# Altium schematic sheet NIM_to_LVCMOS.SchDoc(NIM_to_LVCMOS)
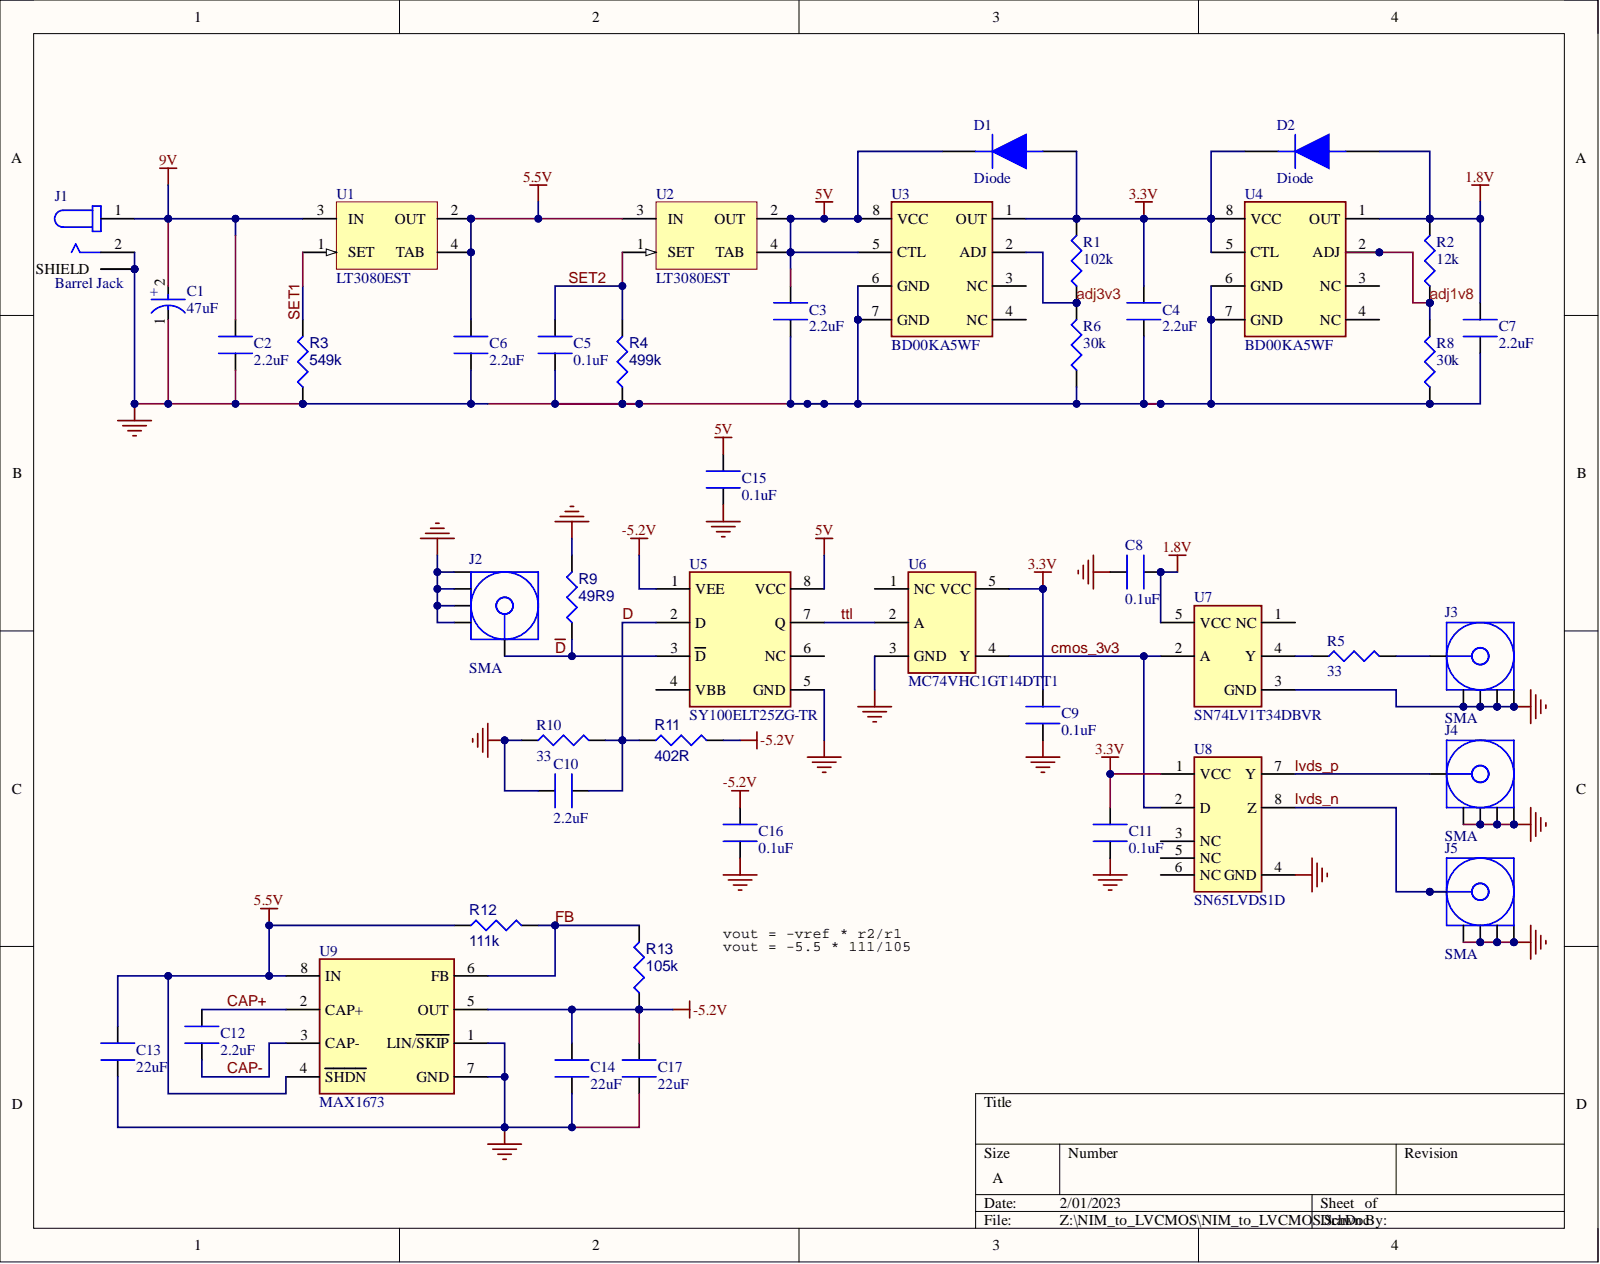
[diagram p0: NIM_to_LVCMOS.SchDoc]

## Parts
C1  47uF  [FP-T495X476K035ATE300-MFG]
C2  2.2uF  [CL21B225KAFNFNE]
C3  2.2uF  [CL21B225KAFNFNE]
C4  2.2uF  [CL21B225KAFNFNE]
C5  0.1uF  [CL21B104KACNNNC]
C6  2.2uF  [CL21B225KAFNFNE]
C7  2.2uF  [CL21B225KAFNFNE]
C8  0.1uF  [CL21B104KACNNNC]
C9  0.1uF  [CL21B104KACNNNC]
C10  2.2uF  [CL21B225KAFNFNE]
C11  0.1uF  [CL21B104KACNNNC]
C12  2.2uF  [CL21B225KAFNFNE]
C13  22uF  [GRM21BR60J226ME39L]
C14  22uF  [GRM21BR60J226ME39L]
C15  0.1uF  [CL21B104KACNNNC]
C16  0.1uF  [CL21B104KACNNNC]
C17  22uF  [GRM21BR60J226ME39L]
D1  Diode  [BA679S-GS08]
D2  Diode  [BA679S-GS08]
J1  Barrel Jack  Connector Type: Jack  [PJ-063AH]
J2  SMA  [RF249BT0050GHDW]
J3  SMA  [RF249BT0050GHDW]
J4  SMA  [RF249BT0050GHDW]
J5  SMA  [RF249BT0050GHDW]
R1  102k  [R0805]
R2  12k  [R0805]
R3  549k  [R0805]
R4  499k  [R0805]
R5  33  [R0805]
R6  30k  [R0805]
R8  30k  [R0805]
R9  49R9  [R0805]
R10  33  [R0805]
R11  402R  [R0805]
R12  111k  [R0805]
R13  105k  [R0805]
U1  LT3080EST  [LT-ST-3-SOT-223_N]
U2  LT3080EST  [LT-ST-3-SOT-223_N]
U3  BD00KA5WF  [BD00KA5WF-E2]
U4  BD00KA5WF  [BD00KA5WF-E2]
U5  SY100ELT25ZG-TR  [SY100ELT25ZG-TR]
U6  MC74VHC1GT14DTT1  [MC74VHC1GT14DTT1]
U7  SN74LV1T34DBVR  [SN74LV1T34-DBV]
U8  SN65LVDS1D  [SN65LVDS1D]
U9  MAX1673  [MAX1673ESA+T]

## Nets
1.8V: C7.2 C8.2 D2.2 R2.2 U4.1(OUT) U7.5(VCC)
3.3V: C4.1 C9.1 C11.1 D1.2 D2.1 R1.2 U3.1(OUT) U4.5(CTL) U4.8(VCC) U6.5(VCC) U8.1(VCC)
5.5V: C6.1 C13.1 R12.1 U1.2(OUT) U1.4(TAB) U2.3(IN) U9.4(SHDN) U9.8(IN)
5V: C3.1 C15.2 D1.1 U2.2(OUT) U2.4(TAB) U3.5(CTL) U3.8(VCC) U5.8(VCC)
9V: C1.2 C2.1 J1.1 U1.3(IN)
-5.2V: C14.1 C16.2 C17.1 R11.2 R13.1 U5.1(VEE) U9.5(OUT)
GND: C1.1 C2.2 C3.2 C4.2 C5.2 C6.2 C7.1 C8.1 C9.2 C10.2 C11.2 C13.2 C14.2 C15.1 C16.1 C17.2 J1.2 J1.S1 J1.S2 J2.2 J2.3 J2.4 J2.5 J3.2 J3.3 J3.4 J3.5 J4.2 J4.3 J4.4 J4.5 J5.2 J5.3 J5.4 J5.5 R3.1 R4.1 R6.1 R8.1 R9.2 R10.1 U3.6(GND) U3.7(GND) U4.6(GND) U4.7(GND) U5.5(GND) U6.3(GND) U7.3(GND) U8.4(GND) U9.1(LIN/SKIP) U9.7(GND)
adj1v8: R2.1 R8.2 U4.2(ADJ)
adj3v3: R1.1 R6.2 U3.2(ADJ)
CAP+: C12.1 U9.2(CAP+)
CAP-: C12.2 U9.3(CAP-)
cmos_3v3: U6.4(Y) U7.2(A) U8.2(D)
D: C10.1 R10.2 R11.1 U5.2(D)
~{D}: J2.1 R9.1 U5.3(D)
FB: R12.2 R13.2 U9.6(FB)
lvds_n: J5.1 U8.8(Z)
lvds_p: J4.1 U8.7(Y)
SET1: R3.2 U1.1(SET)
SET2: C5.1 R4.2 U2.1(SET)
ttl: U5.7(Q) U6.2(A)
NetJ3_1: J3.1 R5.2
NetR5_1: R5.1 U7.4(Y)

Not connected: U3 3(NC) 4(NC); U4 3(NC) 4(NC); U5 4(VBB) 6(NC); U6 1(NC); U7 1(NC); U8 3(NC) 5(NC) 6(NC)

## Library data of the parts
C1 = CMP-2000-05171-2: CAP TANT 47UF 10% 35V 2917; Dielectric Material: Tantalum; Dissipation Factor: 8%; ESR (Equivalent Series Resistance): 300mR; Leakage Current: 16.5uA; Life (Hours): 2000Hour; Polarity: Polar; Ripple Current: 297mA; Capacitance: 47uF; Case Code (Imperial): 2917; Case Code (Metric): 7343; Case/Package: 7343-43; Component Kind: Standard; Construction: Flat; Depth: 4.3mm; Features: General Purpose; Height: 4mm; Height - Seated (Max): 0.169inch; Lead Free: Lead Free; Lead Pitch: 3.8mm; Length: 7.3mm; Library Name: Altium Content Vault; Max Operating Temperature: 125°C; Min Operating Temperature: -55°C; Mount: Surface Mount; Number of Pins: 2; Package Quantity: 1; Packaging: Tape and Reel; Radiation Hardening: No; REACH SVHC: No SVHC; RoHS Compliant: Yes; Series: T495; Termination: SMD/SMT; Tolerance: 10%; Voltage: 35V; Voltage Rating: 35V; Voltage Rating (DC): 35V; Width: 0.169inch
C2-C4 C6 C7 C10 C12 = CL21B225KAFNFNE: CAP CER 2.2UF 25V X7R 0805; Manufacturer: Samsung; Tolerance: 10%; Capacitance: 2.2uF; Case Code (Imperial): 0805; Case Code (Metric): 2012; Case/Package: 0805; Category: Ceramic Capacitors; Component Kind: Standard; ComponentLink1Description: https://datasheet.ciiva.com/25798/cl21b225kafnfne-25798721.pdf?src-supplier=Digi-Key; ComponentLink1URL: https://datasheet.ciiva.com/25798/cl21b225kafnfne-25798721.pdf?src-supplier=Digi-Key; Construction: Flat; Depth: 1.25mm; Dielectric: X7R; Height: 1.25mm; Lead Free: Lead Free; Length: 0.079inch; Manufacturer Part Number: CL21B225KAFNFNE; Max Operating Temperature: 125°C; Min Operating Temperature: -55°C; Mount: Surface Mount; Package Quantity: 1; Packaging: Tape and Reel; Pricing 1: 2000=0.02328, 4000=0.02275, 8000=0.02221, 12000=0.02168, 16000=0.02114 (USD); Radiation Hardening: No; RoHS: Yes; RoHS Compliant: Yes; Series: CL; Stock 1: 46000; Supplier 1: Avnet; Supplier Part Number 1: CL21B225KAFNFNE; Thickness: 0.053inch; Voltage Rating: 25V; Width: 0.049inch; Library Name: NIM_to_LVCMOS_Schlib.SchLib
C5 C8 C9 C11 C15 C16 = CL21B104KACNNNC: CAP CER 0.1UF 25V X7R 0805; Manufacturer: Samsung; Capacitance: 100nF; Tolerance: 10%; Case Code (Imperial): 0805; Case Code (Metric): 2012; Case/Package: 0805; Category: Ceramic Capacitors; Component Kind: Standard; ComponentLink1Description: https://datasheet.ciiva.com/25801/cl21b104kacnnnc-25801824.pdf?src-supplier=Digi-Key; ComponentLink1URL: https://datasheet.ciiva.com/25801/cl21b104kacnnnc-25801824.pdf?src-supplier=Digi-Key; ComponentLink2Description: https://datasheet.ciiva.com/15216/getdatasheetpartid-355204-15216236.pdf?src-supplier=Verical; ComponentLink2URL: https://datasheet.ciiva.com/15216/getdatasheetpartid-355204-15216236.pdf?src-supplier=Verical; Construction: Flat; Contact Plating: Tin; Depth: 1.25mm; Dielectric: X7R; Height: 0.85mm; Length: 2mm; Manufacturer Part Number: CL21B104KACNNNC; Max Operating Temperature: 125°C; Min Operating Temperature: -55°C; Mount: Surface Mount; Package Quantity: 1; Packaging: Tape and Reel; Pricing 1: 1=0.00700, 4000=0.00700 (USD); Radiation Hardening: No; RoHS: Yes; RoHS Compliant: Yes; Series: CL; Stock 1: 16000; Supplier 1: Newark; Supplier Part Number 1: 82AC9426; Thickness: 0.037inch; Voltage: 25V; Voltage Rating: 25V; Width: 0.049inch; Library Name: NIM_to_LVCMOS_Schlib.SchLib
C13 C14 C17 = GRM21BR60J226ME39L: Multilayer Ceramic Capacitors MLCC Surface Mount 0805 22uF 6.3 Volts X5R 20%; Manufacturer: Murata; Voltage: 6.3V; Capacitance: 22uF; Case Code (Imperial): 0805; Case Code (Metric): 2012; Case/Package: 0805; Category: Ceramic Capacitors; Component Kind: Standard; Construction: Flat; Depth: 1.25mm; Dielectric: X5R; Height: 1.25mm; Lead Free: Lead Free; Length: 2mm; Manufacturer Part Number: GRM21BR60J226ME39L; Material: Ceramic; Max Operating Temperature: 85°C; Min Operating Temperature: -55°C; Mount: Surface Mount; Package Quantity: 1; Packaging: Tape and Reel; Pricing 1: 1=0.30000, 25=0.16900, 50=0.14500, 100=0.12100, 250=0.10500, 500=0.08800 (USD); Radiation Hardening: No; Reach SVHC Compliant: Yes; RoHS: Yes; RoHS Compliant: Yes; Series: GRM; Stock 1: 5011; Supplier 1: Newark; Supplier Part Number 1: 24R6338; Termination: SMD/SMT; Thickness: 0.053inch; Tolerance: 20%; Voltage Rating: 6.3V; Voltage Rating (DC): 6.3V; Width: 0.049inch; Library Name: NIM_to_LVCMOS_Schlib.SchLib
D1 D2 = BA679S-GS08: Diode PIN Attenuator 30V 2-Pin Mini-MELF SOD-80 T/R; Manufacturer: Vishay Semiconductors; Current Rating: 50A; Diode Type: PIN - Single; Element Configuration: Single; Forward Current: 50mA; Forward Voltage: 1V; Category: Diodes; Component Kind: Standard; ComponentLink1Description: https://datasheet.ciiva.com/1240/1749354-1240855.pdf?src-supplier=Newark; ComponentLink1URL: https://datasheet.ciiva.com/1240/1749354-1240855.pdf?src-supplier=Newark; ComponentLink2Description: https://datasheet.ciiva.com/9424/ba679-9424319.pdf?src-supplier=Avnet; ComponentLink2URL: https://datasheet.ciiva.com/9424/ba679-9424319.pdf?src-supplier=Avnet; ComponentLink3Description: https://datasheet.ciiva.com/1179/ba679-1179241.pdf?src-supplier=Element14; ComponentLink3URL: https://datasheet.ciiva.com/1179/ba679-1179241.pdf?src-supplier=Element14; Contact Plating: Tin,Silver; Manufacturer Part Number: BA679S-GS08; Max Current Rating: 50mA; Max Operating Temperature: 125°C; Max Repetitive Reverse Voltage (Vrrm): 30V; Min Operating Temperature: -55°C; Mount: Surface Mount; Number of Pins: 2; Package Quantity: 2500; Packaging: Tape and Reel; Pricing 1: 1=0.53000, 10=0.43000, 100=0.29270, 500=0.21954, 1000=0.16466 (USD); Reverse Voltage: 30V; Reverse Voltage (DC): 30V; RoHS: Yes; RoHS Compliant: Yes; Series: -; Stock 1: 7528; Supplier 1: Digi-Key; Supplier Part Number 1: 112-BA679S-GS08CT-ND; Library Name: NIM_to_LVCMOS_Schlib.SchLib
J1 = PJ-063AH: 2.0 x 6.5 mm, 8.0 A, Horizontal, Through Hole, Shielded, Kinked Pins, Dc Power Jack Connector; Manufacturer: CUI; Current Rating: 8A; Gender: Male; Insulation Resistance: 100MR; Number of Conductors: 2; Voltage Rating: 24V; Category: Connectors; Component Kind: Standard; ComponentLink1Description: https://www.cui.com/product/resource/digikeypdf/pj-063ah.pdf?src-supplier=Digi-Key; ComponentLink1URL: https://www.cui.com/product/resource/digikeypdf/pj-063ah.pdf?src-supplier=Digi-Key; ComponentLink2Description: https://www.cui.com/product/resource/digikeypdf/pj-063ah.pdf?src-supplier=Arrow+Electronics; ComponentLink2URL: https://www.cui.com/product/resource/digikeypdf/pj-063ah.pdf?src-supplier=Arrow+Electronics; ComponentLink3Description: https://www.cui.com/product/resource/digikeypdf/pj-063ah.pdf?src-supplier=Mouser; ComponentLink3URL: https://www.cui.com/product/resource/digikeypdf/pj-063ah.pdf?src-supplier=Mouser; ComponentLink4Description: https://www.cui.com/product/resource/digikeypdf/pj-063ah.pdf?src-supplier=Onlinecomponents.com; ComponentLink4URL: https://www.cui.com/product/resource/digikeypdf/pj-063ah.pdf?src-supplier=Onlinecomponents.com; ComponentLink5Description: http://www.cui.com/Product/Resource/FuturePDF/PJ-063AH.pdf?src-supplier=Future+Electronics; ComponentLink5URL: http://www.cui.com/Product/Resource/FuturePDF/PJ-063AH.pdf?src-supplier=Future+Electronics; ComponentLink6Description: https://www.cui.com/product/resource/digikeypdf/pj-063ah.pdf?src-supplier=Verical; ComponentLink6URL: https://www.cui.com/product/resource/digikeypdf/pj-063ah.pdf?src-supplier=Verical; ComponentLink7Description: https://www.cui.com/product/resource/digikeypdf/pj-063ah.pdf?src-supplier=Quest; ComponentLink7URL: https://www.cui.com/product/resource/digikeypdf/pj-063ah.pdf?src-supplier=Quest; ComponentLink8Description: https://www.cui.com/product/resource/digikeypdf/pj-063ah.pdf?src-supplier=NAC+Semi; ComponentLink8URL: https://www.cui.com/product/resource/digikeypdf/pj-063ah.pdf?src-supplier=NAC+Semi; Contact Gender: Male; Contact Material: Copper; Contact Plating: Nickel; Flammability Rating: UL94 V-0; Housing Color: Black; Housing Material: Thermoplastic; Lead Free: Lead Free; Manufacturer Part Number: PJ-063AH; Max Operating Temperature: 85°C; Min Operating Temperature: -25°C; Mount: Through Hole; Orientation: Horizontal; Package Quantity: 500; RoHS: Yes; RoHS Compliant: Yes; Series: -; Shielding: Shielded; Supplier 1: Mouser; Supplier Part Number 1: 490-PJ-063AH; Termination: Through Hole; Library Name: NIM_to_LVCMOS_Schlib.SchLib
J2-J5 = RF2-49B-T-00-50-G-HDW: Conn SMA 0Hz to 6GHz 50Ohm Solder RA Thru-Hole RCP Gold; Manufacturer: Adam Equipment; Category: RF / Coaxial Connectors; Component Kind: Standard; Manufacturer Part Number: RF2-49B-T-00-50-G-HDW; Pricing 1: 1=3.05500, 10=2.75100, 25=2.58300, 50=2.49800, 100=2.35600, 300=2.04400, 500=1.97200 (USD); RoHS: No; Stock 1: 26; Supplier 1: Arrow Electronics; Supplier Part Number 1: RF2-49B-T-00-50-G-HDW; Library Name: NIM_to_LVCMOS_Schlib.SchLib
R1 = RC0805FR-07102KL: Res Thick Film 0805 102K Ohm 1% 1/8W ±100ppm/°C SMD Paper T/R; Manufacturer: Yageo; Max Power Dissipation: 125mW; Resistance: 102kR; Voltage Rating: 150V; Case Code (Imperial): 0805; Case Code (Metric): 2012; Case/Package: 0805; Category: Chip SMD Resistors; Component Kind: Standard; ComponentLink1Description: https://datasheet.ciiva.com/2457/pyu-r-int-thick-7-2457663.pdf?src-supplier=Digi-Key; ComponentLink1URL: https://datasheet.ciiva.com/2457/pyu-r-int-thick-7-2457663.pdf?src-supplier=Digi-Key; ComponentLink2Description: https://datasheet.ciiva.com/2457/pyu-r-int-thick-7-2457664.pdf?src-supplier=Digi-Key; ComponentLink2URL: https://datasheet.ciiva.com/2457/pyu-r-int-thick-7-2457664.pdf?src-supplier=Digi-Key; ComponentLink3Description: https://datasheet.ciiva.com/2457/pyu-r-int-thick-7-2457662.pdf?src-supplier=Digi-Key; ComponentLink3URL: https://datasheet.ciiva.com/2457/pyu-r-int-thick-7-2457662.pdf?src-supplier=Digi-Key; Construction: Rectangular; Contact Plating: Tin,Matte,Lead; Depth: 1.25mm; Features: Moisture Resistant; Height: 0.024inch; Lead Free: Lead Free; Length: 2mm; Manufacturer Part Number: RC0805FR-07102KL; Max Operating Temperature: 155°C; Military Standard: Not; Min Operating Temperature: -55°C; Number of Terminations: 2; Package Quantity: 1; Packaging: Tape and Reel; Power Rating: 125mW; Pricing 1: 10=0.10000, 25=0.02900, 50=0.02100, 100=0.01200 (USD); Radiation Hardening: No; Resistor Type: Thick Film; RoHS: Yes; RoHS Compliant: Yes; Series: RC; Stock 1: 24480; Supplier 1: Newark; Supplier Part Number 1: 32AK1123; Temperature Coefficient: 100ppm/°C; Termination: SMD/SMT; Tolerance: 1%; Voltage Rating (DC): 150V; Width: 0.049inch; Library Name: NIM_to_LVCMOS_Schlib.SchLib
R2 = RC0805JR-0712KL: Res Thick Film 0805 12K Ohm 5% 0.125W(1/8W) ±100ppm/C Pad SMD T/R; Manufacturer: Yageo; Max Power Dissipation: 125mW; Resistance: 12kR; Temperature Coefficient: 100ppm/°C; Voltage Rating: 150V; Case Code (Imperial): 0805; Case Code (Metric): 2012; Case/Package: 0805; Category: Chip SMD Resistors; Component Kind: Standard; ComponentLink1Description: https://datasheet.ciiva.com/7941/442941-7941880.pdf?src-supplier=Element14; ComponentLink1URL: https://datasheet.ciiva.com/7941/442941-7941880.pdf?src-supplier=Element14; ComponentLink2Description: https://datasheet.ciiva.com/7941/442941-7941881.pdf?src-supplier=Element14; ComponentLink2URL: https://datasheet.ciiva.com/7941/442941-7941881.pdf?src-supplier=Element14; ComponentLink3Description: https://datasheet.ciiva.com/17082/rc0805-17082649.pdf?src-supplier=Future+Electronics; ComponentLink3URL: https://datasheet.ciiva.com/17082/rc0805-17082649.pdf?src-supplier=Future+Electronics; Construction: Rectangular; Contact Plating: Tin,Lead; Depth: 1.25mm; Features: Moisture Resistant; Height: 0.5mm; Lead Free: Lead Free; Length: 2mm; Manufacturer Part Number: RC0805JR-0712KL; Max Operating Temperature: 155°C; Military Standard: Not; Min Operating Temperature: -55°C; Number of Terminations: 2; Package Quantity: 5000; Packaging: Cut Tape; Power Rating: 125mW; Pricing 1: 10=0.10000, 25=0.02900, 50=0.02100, 100=0.01300, 250=0.01200, 500=0.01100 (USD); Radiation Hardening: No; Resistance Tolerance: 5%; Resistor Type: Thick Film; RoHS: Yes; RoHS Compliant: Yes; Series: RC; Size Code: 0805; Stock 1: 2308; Supplier 1: Newark; Supplier Part Number 1: 26M6818; Termination: SMD/SMT; Tolerance: 5%; Width: 1.25mm; Library Name: NIM_to_LVCMOS_Schlib.SchLib
R3 = R0805 549k: 549 kOhms ±1% 0.125W, 1/8W Chip Resistor 0805 (2012 Metric) Automotive AEC-Q200, High Voltage Thick Film; Part: KTR10EZPF5493; Component Kind: Standard; Library Name: NIM_to_LVCMOS_Schlib.SchLib
R4 = R0805 499k: 499k kOhms ±1% 0.125W, 1/8W Chip Resistor 0805 (2012 Metric); Part: RC0805FR-07499KL; Component Kind: Standard; Library Name: NIM_to_LVCMOS_Schlib.SchLib
R5 R10 = RC0805FR-0733RL: Res Thick Film 0805 33 Ohm 1% 1/8W +/-100ppm/ßC Molded SMD Paper T/R; Manufacturer: Yageo; Max Power Dissipation: 125mW; Resistance: 33R; Temperature Coefficient: 100ppm/°C; Voltage Rating: 150V; Case Code (Imperial): 0805; Case Code (Metric): 2012; Case/Package: 0805; Category: Chip SMD Resistors; Component Kind: Standard; ComponentLink1Description: https://datasheet.ciiva.com/11808/0900766b8106beab-11808886.pdf?src-supplier=RSComponents; ComponentLink1URL: https://datasheet.ciiva.com/11808/0900766b8106beab-11808886.pdf?src-supplier=RSComponents; ComponentLink2Description: https://datasheet.ciiva.com/46447/444164-46447578.pdf?src-supplier=Element14; ComponentLink2URL: https://datasheet.ciiva.com/46447/444164-46447578.pdf?src-supplier=Element14; ComponentLink3Description: https://datasheet.ciiva.com/2457/pyu-r-int-thick-7-2457741.pdf?src-supplier=Digi-Key; ComponentLink3URL: https://datasheet.ciiva.com/2457/pyu-r-int-thick-7-2457741.pdf?src-supplier=Digi-Key; ComponentLink4Description: https://datasheet.ciiva.com/2457/pyu-r-int-thick-7-2457742.pdf?src-supplier=Digi-Key; ComponentLink4URL: https://datasheet.ciiva.com/2457/pyu-r-int-thick-7-2457742.pdf?src-supplier=Digi-Key; ComponentLink5Description: https://datasheet.ciiva.com/2457/pyu-r-int-thick-7-2457740.pdf?src-supplier=Digi-Key; ComponentLink5URL: https://datasheet.ciiva.com/2457/pyu-r-int-thick-7-2457740.pdf?src-supplier=Digi-Key; ComponentLink6Description: https://datasheet.ciiva.com/5561/rc0805-257173-5561849.pdf?src-supplier=Mouser; ComponentLink6URL: https://datasheet.ciiva.com/5561/rc0805-257173-5561849.pdf?src-supplier=Mouser; ComponentLink7Description: https://datasheet.ciiva.com/7941/444164-7941773.pdf?src-supplier=Element14; ComponentLink7URL: https://datasheet.ciiva.com/7941/444164-7941773.pdf?src-supplier=Element14; ComponentLink8Description: https://datasheet.ciiva.com/7941/444164-7941772.pdf?src-supplier=Element14; ComponentLink8URL: https://datasheet.ciiva.com/7941/444164-7941772.pdf?src-supplier=Element14; ComponentLink9Description: https://datasheet.ciiva.com/17486/rc0805-17486554.pdf?src-supplier=Future+Electronics; ComponentLink9URL: https://datasheet.ciiva.com/17486/rc0805-17486554.pdf?src-supplier=Future+Electronics; Construction: Rectangular; Depth: 1.25mm; Features: Moisture Resistant; Height: 0.5mm; Lead Free: Lead Free; Length: 2mm; Manufacturer Part Number: RC0805FR-0733RL; Max Operating Temperature: 155°C; Military Standard: Not; Min Operating Temperature: -55°C; Number of Terminations: 2; Package Quantity: 1; Packaging: Tape and Reel; Power Rating: 125mW; Pricing 1: 5000=0.00227, 10000=0.00224, 20000=0.00217 (USD); Resistance Tolerance: 1%; Resistor Type: Thick Film; RoHS: Yes; RoHS Compliant: Yes; Series: RC; Size Code: 0805; Stock 1: 25000; Supplier 1: Avnet; Supplier Part Number 1: RC0805FR-0733RL; Technology: THICK FILM; Termination: SMD/SMT; Tolerance: 1%; Width: 0.049inch; Library Name: NIM_to_LVCMOS_Schlib.SchLib
R6 R8 = RC0805JR-0730KL: Res Thick Film 0805 30K Ohm 5% 0.125W(1/8W) ±100ppm/C Pad SMD T/R; Manufacturer: Yageo; Max Power Dissipation: 125mW; Resistance: 30kR; Temperature Coefficient: 100ppm/°C; Voltage Rating: 150V; Case Code (Imperial): 0805; Case Code (Metric): 2012; Case/Package: 0805; Category: Chip SMD Resistors; Component Kind: Standard; ComponentLink1Description: https://datasheet.ciiva.com/16747/rc0805-16747179.pdf?src-supplier=Future+Electronics; ComponentLink1URL: https://datasheet.ciiva.com/16747/rc0805-16747179.pdf?src-supplier=Future+Electronics; Construction: Rectangular; Contact Plating: Tin,Lead,Matte; Depth: 1.25mm; Features: Moisture Resistant; Lead Free: Lead Free; Length: 2mm; Manufacturer Part Number: RC0805JR-0730KL; Max Operating Temperature: 155°C; Military Standard: Not; Min Operating Temperature: -55°C; Number of Terminations: 2; Packaging: Tape and Reel; Power Rating: 125mW; Pricing 1: 10=0.01500, 25=0.01200, 50=0.00900, 100=0.00600 (USD); Radiation Hardening: No; Resistor Type: Thick Film; RoHS: Yes; RoHS Compliant: Yes; Series: RC; Size Code: 0805; Stock 1: 4349; Supplier 1: Newark; Supplier Part Number 1: 82AH8404; Termination: SMD/SMT; Tolerance: 5%; Voltage Rating (DC): 150V; Library Name: NIM_to_LVCMOS_Schlib.SchLib
R9 = R0805 49R9: 49.9 kOhms ±1% 0.125W, 1/8W Chip Resistor 0805 (2012 Metric) Moisture Resistant Thick Film; Part: RC0805FR-0749K9L; Component Kind: Standard; Library Name: NIM_to_LVCMOS_Schlib.SchLib
R11 = R0805 402R: Part: RC0805FR-07402RL; Component Kind: Standard; Library Name: NIM_to_LVCMOS_Schlib.SchLib
R12 = R0805 111k: 111 kOhms ±1% 0.125W, 1/8W Chip Resistor 0805 (2012 Metric); Part: RNCP0805FTD11K0; Component Kind: Standard; Library Name: NIM_to_LVCMOS_Schlib.SchLib
R13 = R0805 105k: 105 kOhms ±1% 0.125W, 1/8W Chip Resistor 0805 (2012 Metric); Part: RMCF0805FT105K; Component Kind: Standard; Library Name: NIM_to_LVCMOS_Schlib.SchLib
U1 U2 = CMP-0472-00054-1: Adjustable 1.1 A Single Resistor Low Dropout Regulator, 0.3 mA Isupply, 3-pin SOT223 (ST-3), -40 to 125 degC; Manufacturer: Linear Technology; Extended Temp: E, I; Initial Accuracy(%): 1; Isupply(mA): 0.3; Vin Max(V): 36; Vin Min(V): 1.2; Component Kind: Standard; ComponentLink1Description: Manufacturer URL; ComponentLink1URL: http://www.linear.com/; ComponentLink2Description: Datasheet; ComponentLink2URL: http://cds.linear.com/docs/en/datasheet/3080fc.pdf; ComponentLink3Description: Package Specification; ComponentLink3URL: http://cds.linear.com/docs/en/packaging/SOT_3_05-08-1630.pdf; DatasheetVersion: Rev. F; Library Name: Altium Content Vault; Mounting Technology: Surface Mount; PackageDescription: 3-Pin Plastic SOT-223, Body 3.505 x 6.505 mm, Pitch 2.3 mm; PackageReference: ST-3; PackageVersion: Jun-10; PartNumber: LT3080EST; RoHS: FALSE
U3 U4 = BD00KA5WF-E2: LDO Regulator Pos 1V to 4V 0.5A Automotive 8-Pin SOP T/R; Manufacturer: Rohm; Dropout Voltage: 120mV; Max Input Voltage: 5.5V; Max Output Current: 500mA; Max Power Dissipation: 687.6mW; Min Input Voltage: 2.3V; Number of Outputs: 1; Output Type: Adjustable; Polarity: Positive; Reference Voltage: 758mV; Case/Package: SOP; Category: Voltage Regulators - Linear; Component Kind: Standard; ComponentLink1Description: https://datasheet.ciiva.com/26878/getdatasheetpartid-457808-26878767.pdf?src-supplier=Verical; ComponentLink1URL: https://datasheet.ciiva.com/26878/getdatasheetpartid-457808-26878767.pdf?src-supplier=Verical; ComponentLink2Description: https://datasheet.ciiva.com/22732/1747249-22732896.pdf?src-supplier=Element14; ComponentLink2URL: https://datasheet.ciiva.com/22732/1747249-22732896.pdf?src-supplier=Element14; ComponentLink3Description: https://datasheet.ciiva.com/2040/bdxxka5-e-2040541.pdf?src-supplier=Digi-Key; ComponentLink3URL: https://datasheet.ciiva.com/2040/bdxxka5-e-2040541.pdf?src-supplier=Digi-Key; ComponentLink4Description: https://datasheet.ciiva.com/2040/bdxxka5-e-2040542.pdf?src-supplier=Digi-Key; ComponentLink4URL: https://datasheet.ciiva.com/2040/bdxxka5-e-2040542.pdf?src-supplier=Digi-Key; ComponentLink5Description: https://datasheet.ciiva.com/2040/bdxxka5-e-2040543.pdf?src-supplier=Digi-Key; ComponentLink5URL: https://datasheet.ciiva.com/2040/bdxxka5-e-2040543.pdf?src-supplier=Digi-Key; Contact Plating: Copper,Tin; Lead Free: Lead Free; Manufacturer Part Number: BD00KA5WF-E2; Max Operating Temperature: 105°C; Max Output Voltage: 4V; Min Operating Temperature: -40°C; Min Output Voltage: 1V; Mount: Surface Mount; Number of Pins: 8; Number of Regulators: 1; Output Current: 500mA; Output Voltage: 4V; Package Quantity: 1; Packaging: Tape and Reel; Pricing 1: 1=1.69000, 10=1.50700, 25=1.43040, 100=1.17510, 250=1.09848, 500=0.97074, 1000=0.76638 (USD); Radiation Hardening: No; RoHS: Yes; RoHS Compliant: Yes; Series: -; Stock 1: 9113; Supplier 1: Digi-Key; Supplier Part Number 1: BD00KA5WF-E2CT-ND; Library Name: NIM_to_LVCMOS_Schlib.SchLib
U5 = SY100ELT25ZG-TR: Dual Diff Ecl To Ttl Translator, 8 Soic 3.90Mm(.150In) T/R; Manufacturer: Microchip; Channel Type: Unidirectional; High Level Output Current: -3mA; Low Level Output Current: 24mA; Max Supply Voltage: 5.5V; Min Supply Voltage: 4.5V; Number of Bits: 1; Operating Supply Voltage: 5V; Propagation Delay: 3.6ns; Case/Package: SOIC; Category: Clock Buffers, Drivers; Component Kind: Standard; ComponentLink1Description: https://datasheet.ciiva.com/1901/sy100elt25-1901076.pdf?src-supplier=Digi-Key; ComponentLink1URL: https://datasheet.ciiva.com/1901/sy100elt25-1901076.pdf?src-supplier=Digi-Key; Lead Free: Lead Free; Logic Function: Translator; Manufacturer Part Number: SY100ELT25ZG-TR; Max Operating Temperature: 85°C; Min Operating Temperature: -40°C; Mount: Surface Mount; Number of Channels: 1; Number of Circuits: 1; Number of Pins: 8; Output Type: TTL; Package Quantity: 1000; Packaging: Tape and Reel; Pricing 1: 1=4.65000, 25=3.87960, 100=3.52980 (USD); RoHS: Yes; RoHS Compliant: Yes; Series: 100ELT; Stock 1: 1000; Supplier 1: Digi-Key; Supplier Part Number 1: 150-SY100ELT25ZG-TRCT-ND; Technology: ECL; Turn-On Delay Time: 3.6ns; Library Name: NIM_to_LVCMOS_Schlib.SchLib
U6 = MC74VHC1GT14DTT1: Inverter Schmitt Trigger Sgl Cmos 5TSOP; Manufacturer: ON Semiconductor; High Level Output Current: -8mA; Logic Function: Schmitt Trigger,Inverter; Low Level Output Current: 8mA; Max Supply Voltage: 5.5V; Min Supply Voltage: 3V; Number of Circuits: 1; Case/Package: SOT-23-5; Category: Logic Gates; Component Kind: Standard; ComponentLink1Description: https://datasheet.ciiva.com/4828/mc74vhc1gt14-d-107443-4828755.pdf?src-supplier=Mouser; ComponentLink1URL: https://datasheet.ciiva.com/4828/mc74vhc1gt14-d-107443-4828755.pdf?src-supplier=Mouser; ComponentLink2Description: https://datasheet.ciiva.com/7970/mc74vhc1gt14-d-7970445.pdf?src-supplier=Element14; ComponentLink2URL: https://datasheet.ciiva.com/7970/mc74vhc1gt14-d-7970445.pdf?src-supplier=Element14; Lead Free: Contains Lead; Manufacturer Part Number: MC74VHC1GT14DTT1; Max Operating Temperature: 125°C; Min Operating Temperature: -55°C; Number of Gates: 1; Number of Inputs: 1; Number of Pins: 5; Output Current: 8mA; Package Quantity: 3000; Packaging: Tape and Reel; Reach SVHC Compliant: Yes; RoHS: No; RoHS Compliant: No; Supplier 1: Mouser; Supplier Part Number 1: 863-MC74VHC1GT14DTT1; Library Name: NIM_to_LVCMOS_Schlib.SchLib
U7 = SN74LV1T34DBVR: IC BUFFER GATE SGL CMOS SOT23-5; Manufacturer: Texas Instruments; High Level Output Current: -8mA; Logic Function: Buffer,Inverting; Low Level Output Current: 8mA; Max Supply Voltage: 5.5V; Min Supply Voltage: 1.6V; Number of Bits: 1; Output Type: PUSH-PULL,3-STATE; Polarity: Non-Inverting; Propagation Delay: 15.5ns; Quiescent Current: 1uA; Case/Package: SOT-23-5; Category: Buffers, Drivers and Transceivers; Component Kind: Standard; ComponentLink1Description: https://datasheet.ciiva.com/29634/sn74lv1t34-29634759.pdf?src-supplier=Digi-Key; ComponentLink1URL: https://datasheet.ciiva.com/29634/sn74lv1t34-29634759.pdf?src-supplier=Digi-Key; ComponentLink2Description: https://datasheet.ciiva.com/29634/sn74lv1t34-29634758.pdf?src-supplier=Digi-Key; ComponentLink2URL: https://datasheet.ciiva.com/29634/sn74lv1t34-29634758.pdf?src-supplier=Digi-Key; ComponentLink3Description: https://datasheet.ciiva.com/29634/sn74lv1t34-29634760.pdf?src-supplier=Digi-Key; ComponentLink3URL: https://datasheet.ciiva.com/29634/sn74lv1t34-29634760.pdf?src-supplier=Digi-Key; ComponentLink4Description: https://datasheet.ciiva.com/29888/sn74lv1t34-29888041.pdf?src-supplier=Avnet; ComponentLink4URL: https://datasheet.ciiva.com/29888/sn74lv1t34-29888041.pdf?src-supplier=Avnet; Lead Free: Lead Free; Manufacturer Part Number: SN74LV1T34DBVR; Max Operating Temperature: 125°C; Min Operating Temperature: -40°C; Mount: Surface Mount; Number of Bits per Element: 1; Number of Channels: 1; Number of Elements: 1; Number of Input Lines: 1; Number of Inputs: 1; Number of Output Lines: 1; Number of Outputs: 1; Number of Pins: 5; Operating Supply Current: 8mA; Package Quantity: 3000; Packaging: Tape and Reel; Pricing 1: 1=0.51900, 10=0.42320, 100=0.26150, 500=0.21660, 1000=0.16270, 3000=0.14770 (USD); Radiation Hardening: No; Reach SVHC Compliant: Yes; RoHS: Yes; RoHS Compliant: Yes; Series: 74LV; Stock 1: 1800; Supplier 1: Arrow Electronics; Supplier Part Number 1: SN74LV1T34DBVR; Technology: CMOS; Weight: 0.000353oz; Library Name: NIM_to_LVCMOS_Schlib.SchLib
U8 = SN65LVDS1D: 630-Mbps single LVDS driver 8-SOIC -40 to 85; Manufacturer: Texas Instruments; Data Rate: 630Mbps; Max Power Dissipation: 725mW; Max Supply Voltage: 3.6V; Min Supply Voltage: 2.4V; Nominal Supply Current: 15mA; Number of Drivers: 1; Operating Supply Voltage: 3.3V; Propagation Delay: 3.1ns; Case/Package: SOIC; Category: Interface ICs; Component Kind: Standard; ComponentLink1Description: https://datasheet.ciiva.com/15151/getdatasheetpartid-163203-15151570.pdf?src-supplier=Verical; ComponentLink1URL: https://datasheet.ciiva.com/15151/getdatasheetpartid-163203-15151570.pdf?src-supplier=Verical; ComponentLink2Description: https://datasheet.ciiva.com/1771/sn65lvds1-1771428.pdf?src-supplier=Digi-Key; ComponentLink2URL: https://datasheet.ciiva.com/1771/sn65lvds1-1771428.pdf?src-supplier=Digi-Key; ComponentLink3Description: https://datasheet.ciiva.com/3151/sn65lvdt2-3151544.pdf?src-supplier=Avnet; ComponentLink3URL: https://datasheet.ciiva.com/3151/sn65lvdt2-3151544.pdf?src-supplier=Avnet; ComponentLink4Description: https://datasheet.ciiva.com/41461/001187532-da-01-en-ic-hs-diff-line-dr-sn65lvds1d-soic-8-tid-41461269.pdf?src-supplier=Conrad; ComponentLink4URL: https://datasheet.ciiva.com/41461/001187532-da-01-en-ic-hs-diff-line-dr-sn65lvds1d-soic-8-tid-41461269.pdf?src-supplier=Conrad; Height: 1.58mm; Lead Free: Lead Free; Length: 4.9mm; Manufacturer Part Number: SN65LVDS1D; Max Operating Temperature: 85°C; Min Operating Temperature: -40°C; Mount: Surface Mount; Number of Elements: 1; Number of Pins: 8; Number of Receivers: 1; Package Quantity: 75; Packaging: Rail/Tube; Power Dissipation: 725mW; Pricing 1: 1=2.57000, 10=2.32000, 25=2.19000, 50=2.19000, 75=1.86000, 100=1.86000, 300=1.74000, 525=1.53000, 1000=1.53000, 1050=1.27000, 10000=1.27000 (USD); Radiation Hardening: No; Reach SVHC Compliant: Yes; RoHS: Yes; RoHS Compliant: Yes; Series: 65LVDS; Stock 1: 778; Supplier 1: Mouser; Supplier Part Number 1: 595-SN65LVDS1D; Supply Current: 15mA; Turn-On Delay Time: 3.1ns; Weight: 0.002561oz; Width: 3.91mm; Library Name: NIM_to_LVCMOS_Schlib.SchLib
U9 = MAX1673ESA+T: 5.5 V 125 mA 460kHz Charge Pump SMT Switching Regulator - SOIC-8; Manufacturer: Maxim; Logic Function: Inverting; Max Input Voltage: 5.5V; Number of Outputs: 1; Output Current: 125mA; Output Type: Adjustable; Output Voltage: -3.15V; Switching Frequency: 350kHz; Synchronous Rectifier: No; Case/Package: SOIC; Category: Voltage Regulators - Switching; Component Kind: Standard; ComponentLink1Description: https://datasheet.ciiva.com/24013/max1673-85133-24013456.pdf?src-supplier=Mouser; ComponentLink1URL: https://datasheet.ciiva.com/24013/max1673-85133-24013456.pdf?src-supplier=Mouser; ComponentLink2Description: https://datasheet.ciiva.com/3216/max1673-3216094.pdf?src-supplier=Avnet; ComponentLink2URL: https://datasheet.ciiva.com/3216/max1673-3216094.pdf?src-supplier=Avnet; ComponentLink3Description: https://datasheet.ciiva.com/2037/max1673-2037237.pdf?src-supplier=Digi-Key; ComponentLink3URL: https://datasheet.ciiva.com/2037/max1673-2037237.pdf?src-supplier=Digi-Key; Lead Free: Lead Free; Manufacturer Part Number: MAX1673ESA+T; Max Operating Temperature: 85°C; Min Operating Temperature: -40°C; Mount: Surface Mount; Package Quantity: 2500; Packaging: Tape and Reel; Pricing 1: 1=6.45840, 10=5.76150, 25=5.40040, 100=4.64370, 250=4.36660, 500=3.88360, 1000=3.47760 (USD); Radiation Hardening: No; RoHS: Yes; RoHS Compliant: Yes; Series: -; Stock 1: 1576; Supplier 1: Arrow Electronics; Supplier Part Number 1: MAX1673ESA+T; Width: 4mm; Library Name: NIM_to_LVCMOS_Schlib.SchLib
